# Altium schematic sheet side-Panel.SchDoc(side-Panel)
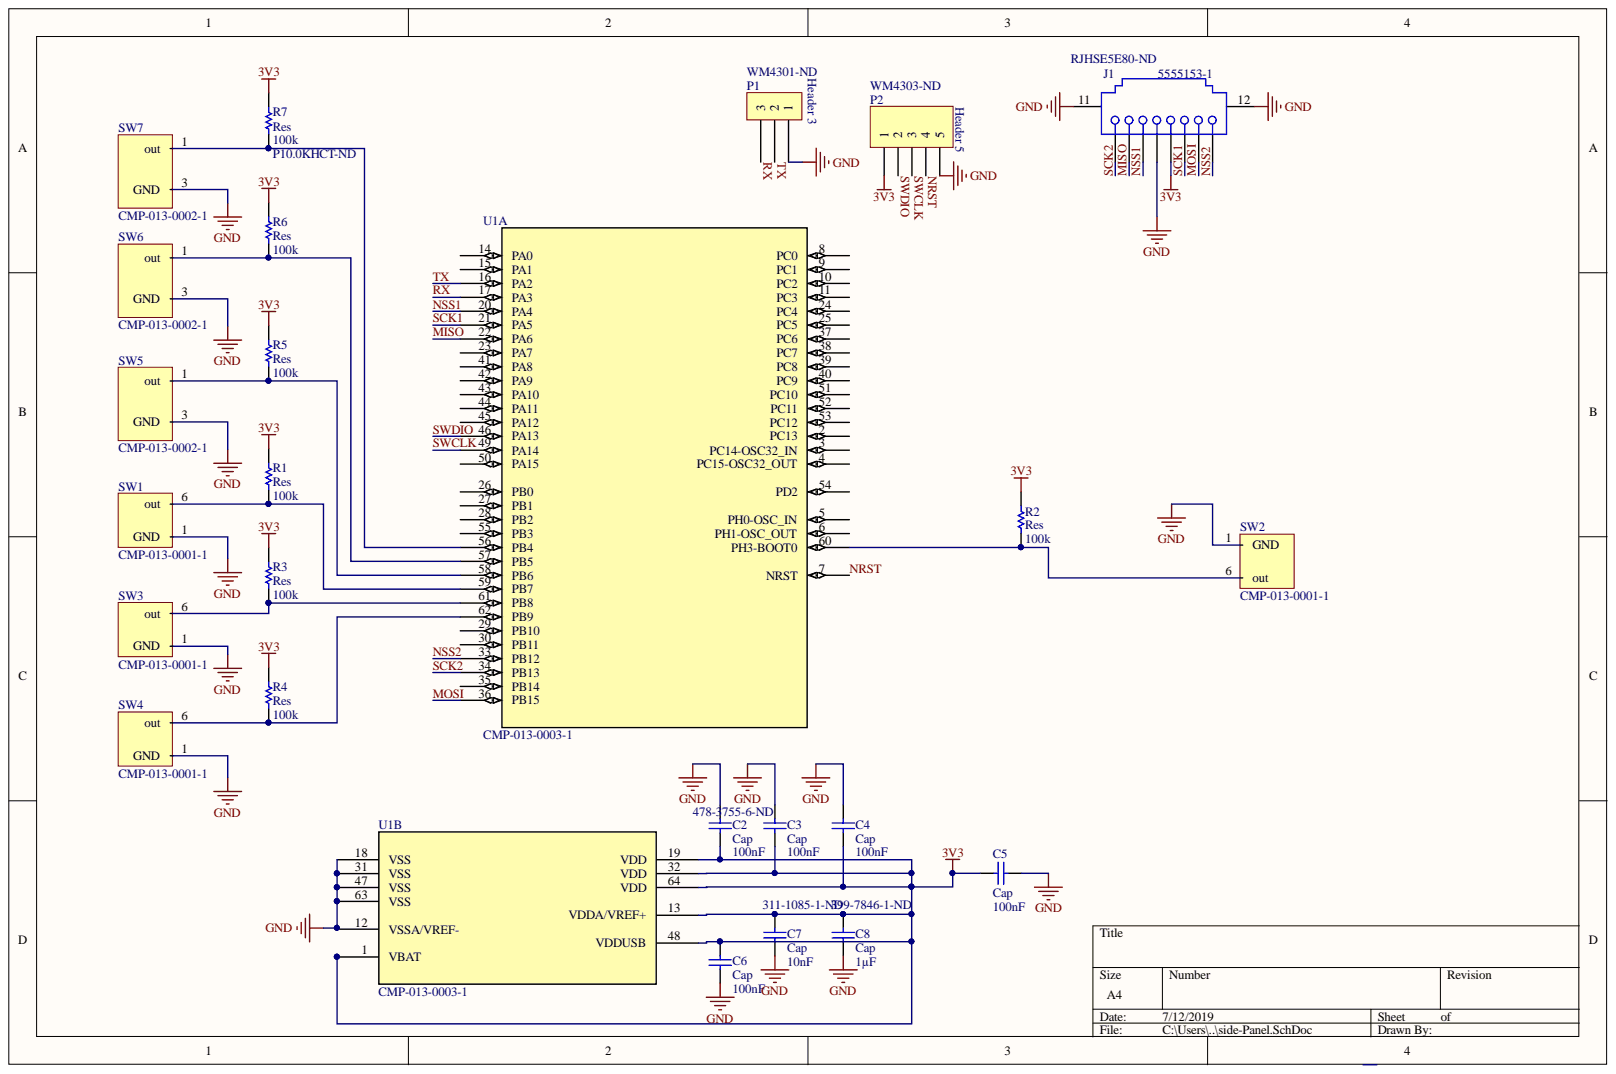
[diagram p0: side-Panel.SchDoc]

## Parts
C2  Cap  value 100nF  Supplier Part Number 1: 478-3755-6-ND  [1608[0603]]
C3  Cap  value 100nF  [1608[0603]]
C4  Cap  value 100nF  [1608[0603]]
C5  Cap  value 100nF  [1608[0603]]
C6  Cap  value 100nF  [1608[0603]]
C7  Cap  value 10nF  Supplier Part Number 1: 311-1085-1-ND  [1608[0603]]
C8  Cap  value 1µF  Supplier Part Number 1: 399-7846-1-ND  [1608[0603]]
J1  5555153-1  Supplier Part Number 1: RJHSE5E80-ND  [RJ45 - CHN + DIGIKEY]
P1  Header 3  Supplier Part Number 1: WM4301-ND  [HDR1X3]
P2  Header 5  Supplier Part Number 1: WM4303-ND  [HDR1X5]
R1  Res  value 100k  [1608[0603]]
R2  Res  value 100k  [1608[0603]]
R3  Res  value 100k  [1608[0603]]
R4  Res  value 100k  [1608[0603]]
R5  Res  value 100k  [1608[0603]]
R6  Res  value 100k  [1608[0603]]
R7  Res  value 100k  Supplier Part Number 1: P10.0KHCT-ND  [1608[0603]]
SW1  CMP-013-0001-1  [latch]
SW2  CMP-013-0001-1  [latch]
SW3  CMP-013-0001-1  [latch]
SW4  CMP-013-0001-1  [latch]
SW5  CMP-013-0002-1  [throw-switch]
SW6  CMP-013-0002-1  [throw-switch]
SW7  CMP-013-0002-1  [throw-switch]
U1  CMP-013-0003-1  [STM32L4A6RG]  (parts A,B)

## Nets
3V3: C2.1 C3.1 C4.1 C5.1 C6.1 C7.1 C8.1 J1.5 P2.1 R1.2 R2.2 R3.1 R4.2 R5.2 R6.2 R7.2 U1.1(VBAT) U1.13(VDDA/VREF+) U1.19(VDD) U1.32(VDD) U1.48(VDDUSB) U1.64(VDD)
GND: C2.2 C3.2 C4.2 C5.2 C6.2 C7.2 C8.2 J1.4 J1.11 J1.12 P1.1 P2.5 SW1.1 SW2.1 SW3.1 SW4.1 SW5.3 SW6.3 SW7.3 U1.12(VSSA/VREF-) U1.18(VSS) U1.31(VSS) U1.47(VSS) U1.63(VSS)
MISO: J1.2 U1.22(PA6)
MOSI: J1.7 U1.36(PB15)
NRST: P2.4 U1.7(NRST)
NSS1: J1.3 U1.20(PA4)
NSS2: J1.8 U1.33(PB12)
RX: P1.3 U1.17(PA3)
SCK1: J1.6 U1.21(PA5)
SCK2: J1.1 U1.34(PB13)
SWCLK: P2.3 U1.49(PA14)
SWDIO: P2.2 U1.46(PA13)
TX: P1.2 U1.16(PA2)
NetR1_1: R1.1 SW1.6 U1.59(PB7)
NetR2_1: R2.1 SW2.6 U1.60(PH3-BOOT0)
NetR3_2: R3.2 SW3.6 U1.61(PB8)
NetR4_1: R4.1 SW4.6 U1.62(PB9)
NetR5_1: R5.1 SW5.1 U1.58(PB6)
NetR6_1: R6.1 SW6.1 U1.57(PB5)
NetR7_1: R7.1 SW7.1 U1.56(PB4)
N00005:

Not connected: U1 2(PC13) 3(PC14-OSC32_IN) 4(PC15-OSC32_OUT) 5(PH0-OSC_IN) 6(PH1-OSC_OUT) 8(PC0) 9(PC1) 10(PC2) 11(PC3) 14(PA0) 15(PA1) 23(PA7) 24(PC4) 25(PC5) 26(PB0) 27(PB1) 28(PB2) 29(PB10) 30(PB11) 35(PB14) 37(PC6) 38(PC7) 39(PC8) 40(PC9) 41(PA8) 42(PA9) 43(PA10) 44(PA11) 45(PA12) 50(PA15) 51(PC10) 52(PC11) 53(PC12) 54(PD2) 55(PB3)

## Library data of the parts
C2-C8 = Cap: Capacitor; Simulation: CAP; Signal Integrity: Cap; Published: 8-Jun-2000; PackageReference: RAD-0.3; LatestRevisionNote: Re-released for DXP Platform.; LatestRevisionDate: 17-Jul-2002; Component Kind: Standard; Publisher: Altium Limited; Library Name: blueskyLib.SchLib
  C2 C7 C8: Supplier 1: Digi-Key
J1 = CMP-2000-05640-1: RJ45 Connector, Pitch 1.27 mm, 8 Position, Height 13.08 mm, Tail Length 3.81 mm, -40 to 85 degC, RoHS, Tray; Manufacturer: TE Connectivity; Tab Direction: Down; Shielding: Shielded; Ratings: Cat3; Other Names: A31438; Number of Positions/Contacts: 8p8c(RJ45,Ethernet); Number of Ports: 1; LED Color: DoesNotContainLED; Dynamic Catalog: ModularJacks; Connector Type: Jack; Catalog Drawings: JackSideEntryRightAngle    JackSideEntryRightAngleTop; 3D Model: 5555153-1.pdf; Termination: Solder; Supplier 1: Digi-Key; Standard Package: 136; RoHS Information: 5555153-1StatementofCompliance; Packaging: Tray; Package Reference: 5555153-1; Package Description: RJ45 Connector, Pitch 1.27 mm, 8 Position, Height 13.08 mm, Tail Length 3.81 mm; Orientation: 90°Angle(Right); Operating Temperature: -40°C~85°C; Number of Rows: 1; Mounting Type: ThroughHole; Mounting Technology: ThroughHole; Manufacturer URL: http://www.te.com/; Housing Material: PolybutyleneTerephthalate(PBT),Polyester; Features: BoardLock,PanelStops; Family: ModularConnectors-Jacks; Datasheet Version: Rev. D2, 05/2016; Datasheet URL: http://www.te.com/commerce/DocumentDelivery/DDEController?Action=srchrtrv&DocNm=5555153&DocType=Customer+Drawing&DocLang=English&DocFormat=pdf&PartCntxt=5555153-1; Contact Material: PhosphorBronze; Contact Finish Thickness: 50µin(1.27µm); Contact Finish: Gold; Component Kind: Standard; Category: Connectors,Interconnects; Library Name: sharonLib.SchLib
P1 = Header 3: Jumper, 3-pin; Supplier 1: Digi-Key; Component Kind: Standard; Library Name: blueskyLib.SchLib
P2 = Header 5: Header, 5-Pin; Supplier 1: Digi-Key; Signal Integrity: Connector; LatestRevisionNote: Re-released for DXP Platform.; LatestRevisionDate: 17-Jul-2002; Component Kind: Standard; Publisher: Altium Limited; Library Name: blueskyLib.SchLib
R1-R7 = Res: Rectangular Thick Film Chip Resistor, 10 Ohm to 1M Ohm Range, 0.1% and 0.5% Tolerance, 0805 Size, 0.1 W; Note: If flow soldering, adjust pad width of PCB Footprint to 0.7 - 0.8 times the width of the resistor.; Simulation: RESISTOR; Signal Integrity: Res; Published: 17-Dec-2003; PackageReference: ERA-0805; PackageDocument: Mar-2003; LatestRevisionNote: Silkscreen size reduced.; LatestRevisionDate: 19-Mar-2004; ComponentLink2URL: http://www.panasonic.com/industrial/components/pdf/AOA0000CE8.pdf; ComponentLink2Description: Datasheet; ComponentLink1URL: http://www.panasonic.com/industrial/components/resistive_products.htm; ComponentLink1Description: Manufacturer Link; Component Kind: Standard; Code_IPC: 2012 [0805]; Publisher: Altium Limited; Library Name: blueskyLib.SchLib
  R7: Supplier 1: Digi-Key
SW1-SW4 = CMP-013-0001-1: SWITCH PUSHBUTTON SPST 1A 30V; Component Name: CW181-ND; Library Name: <Company> Vault; Component Kind: Standard; Source: sharonLib.SchLib
SW5-SW7 = CMP-013-0002-1: SWITCH THROW/TOGGLE SPST 0.4VA 20V; Component Name: CKN9050TR-ND; Library Name: <Company> Vault; Component Kind: Standard; Source: sharonLib.SchLib
U1 = CMP-013-0003-1: STM32 Chip; Manufacturer_Part_Number: stm32l4x6rxt6; Vendor: STMicroelectronics; C: Copyright (C) 2016 Accelerated Designs. All rights reserved; Value: Value; Type: DEV; RefDes: RefDes; Library Name: <Company> Vault; Component Name: STM32L4x6RxT6; Component Kind: Standard; Source: sharonLib.SchLib; Copyright: Copyright (C) 2016 Accelerated Designs. All rights reserved
